# Altium schematic sheet FMDABRadio.SchDoc
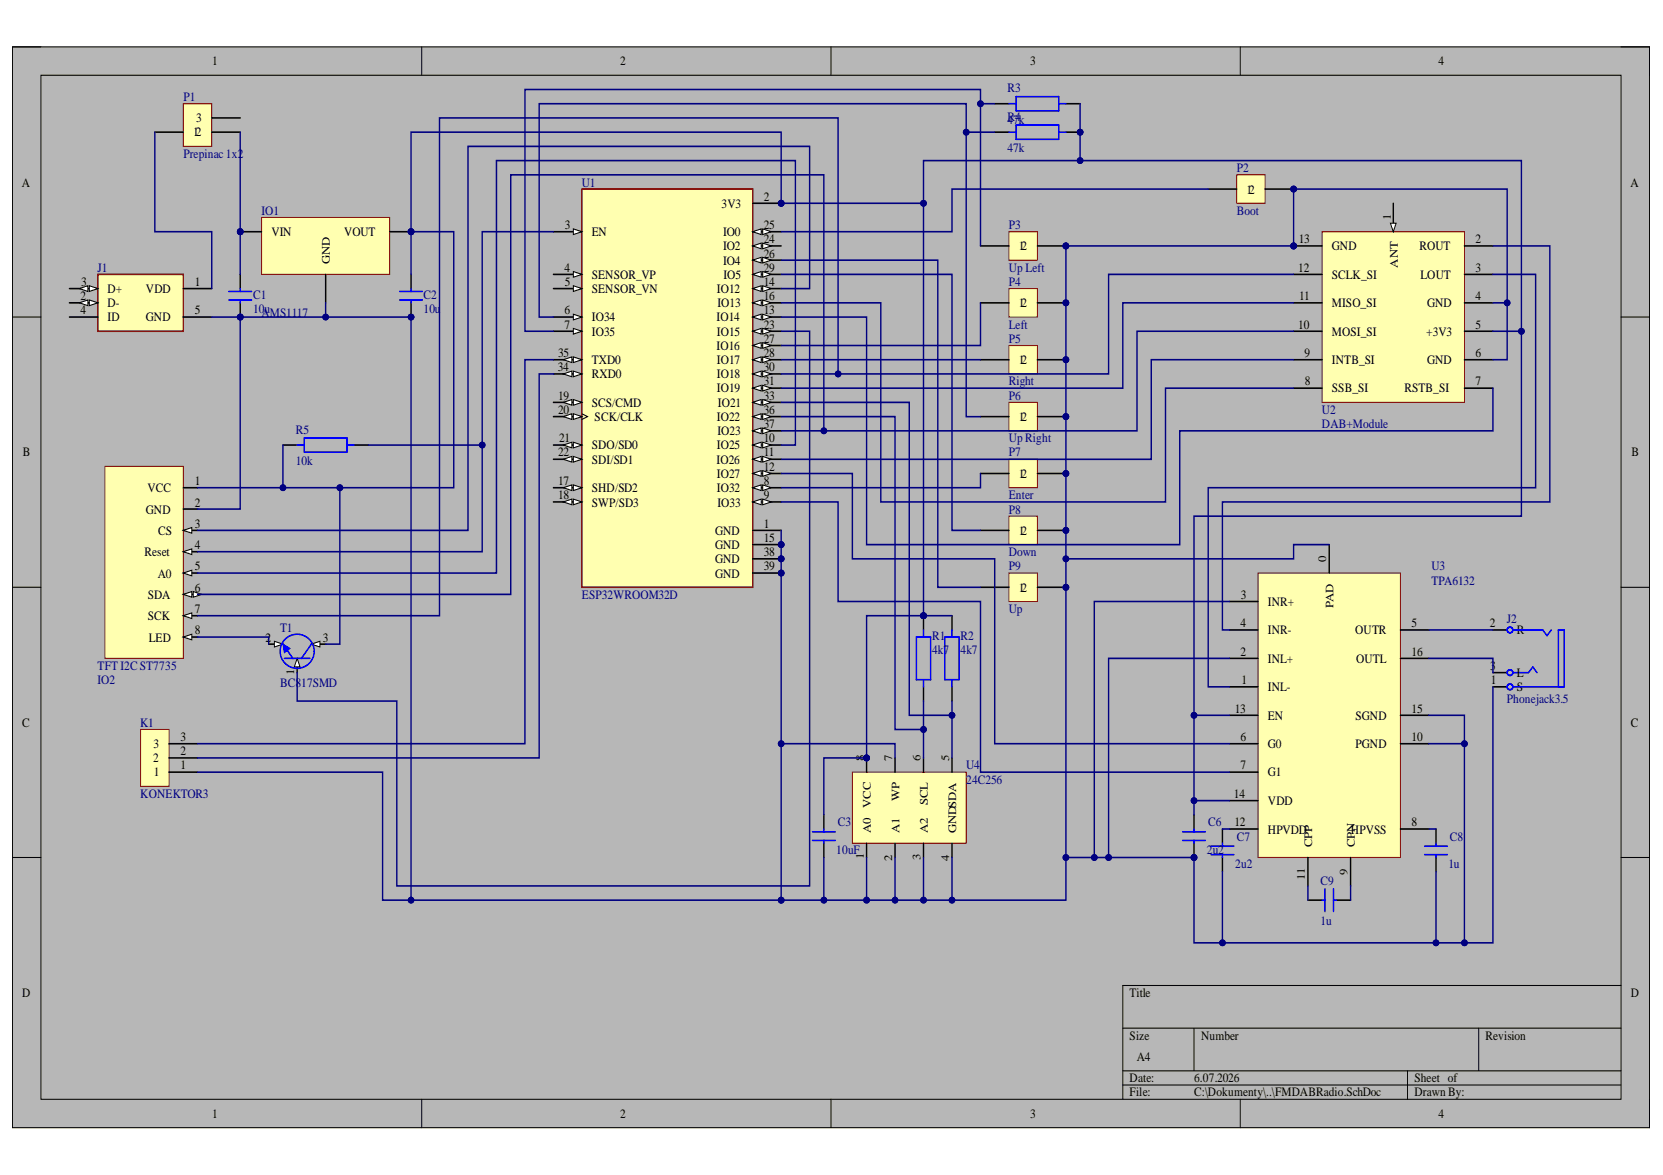
[diagram p0: FMDABRadio.SchDoc]

## Parts
C1  10u  [SMD0805]
C2  10u  [SMD0805]
C3  10uF  [SMD0805]
C6  2u2  [SMD0805]
C7  2u2  [SMD0805]
C8  1u  [SMD0805]
C9  1u  [SMD0805]
IO1  AMS1117  [SOT229]
IO2  TFT 1.8in I2C ST7735  [TFT I2C 1.8in]  (value not drawn)
J1  USBMiniB  [USBMicro SMT V2]  (value not drawn)
J2  Phonejack3.5  [Jack 3.5 mini]
K1  KONEKTOR3  [IL3]
P1  Prepinac 1x2  [Prepinac 1x2 4.8 H]
P2  Boot  [Mikrospinac - SMD]
P3  Up Left  [Mikrospinac]
P4  Left  [Mikrospinac]
P5  Right  [Mikrospinac]
P6  Up Right  [Mikrospinac]
P7  Enter  [Mikrospinac]
P8  Down  [Mikrospinac]
P9  Up  [Mikrospinac]
R1  4k7  [SMD0805]
R2  4k7  [SMD0805]
R3  47k  [SMD0805]
R4  47k  [SMD0805]
R5  10k  [SMD0805]
T1  BC817SMD  [SOT23-3]
U1  ESP32WROOM32D  [ESP32WROOM32D]
U2  DAB+Module  [DAB+Module]
U3  TPA6132  [QFN-16]
U4  24C256  [SO8]

## Nets
5: IO2.6(SDA) IO2.7(SCK) IO2.8(LED)
NetC1_1: C1.1 IO1.3 P1.2
NetC1_2: C1.2 C2.2 C3.2 C6.2 C7.2 C8.2 IO1.1 IO2.2(GND) J1.5(GND) J2.1 K1.1 P2.2 P3.2 P4.2 P5.2 P6.2 P7.2 P8.2 P9.2 U1.1(GND) U1.15(GND) U1.38(GND) U1.39(GND) U2.4(GND) U2.6(GND) U2.13(GND) U3.0(PAD) U3.2(INL+) U3.3(INR+) U3.10(PGND) U3.15(SGND) U4.1(A0) U4.2(A1) U4.3(A2) U4.4(GND) U4.7(WP)
NetC2_1: C2.1 C3.1 C6.1 IO1.2 IO2.1(VCC) R1.1 R2.1 R3.2 R4.2 R5.1 T1.3 U1.2(3V3) U2.5(+3V3) U3.13(EN) U3.14(VDD) U4.8(VCC)
NetC7_1: C7.1 U3.12(HPVDD)
NetC8_1: C8.1 U3.8(HPVSS)
NetC9_1: C9.1 U3.11(CPP)
NetC9_2: C9.2 U3.9(CPN)
NetIO2_3: IO2.3(CS) U1.14(IO12)
NetIO2_4: IO2.4(Reset) R5.2 U1.3(EN)
NetIO2_5: IO2.5(A0) U1.10(IO25)
NetIO2_6: IO2.6(SDA) U1.37(IO23) U2.10(MOSI_SI)
NetIO2_7: IO2.7(SCK) U1.30(IO18) U2.12(SCLK_SI)
NetIO2_8: IO2.8(LED) T1.2
NetJ1_1: J1.1(VDD) P1.1
NetJ2_2: J2.2 U3.5(OUTR)
NetJ2_3: J2.3 U3.16(OUTL)
NetK1_2: K1.2 U1.34(RXD0)
NetK1_3: K1.3 U1.35(TXD0)
NetP2_1: P2.1 U1.25(IO0)
NetP3_1: P3.1 R3.1 U1.7(IO35)
NetP4_1: P4.1 U1.27(IO16)
NetP5_1: P5.1 U1.28(IO17)
NetP6_1: P6.1 R4.1 U1.6(IO34)
NetP7_1: P7.1 U1.8(IO32)
NetP8_1: P8.1 U1.29(IO5)
NetP9_1: P9.1 U1.26(IO4)
NetR1_2: R1.2 U1.36(IO22) U4.6(SCL)
NetR2_2: R2.2 U1.33(IO21) U4.5(SDA)
NetT1_1: T1.1 U1.23(IO15)
NetU1_9: U1.9(IO33) U3.7(G1)
NetU1_11: U1.11(IO26) U2.9(INTB_SI)
NetU1_12: U1.12(IO27) U3.6(G0)
NetU1_13: U1.13(IO14) U2.7(RSTB_SI)
NetU1_16: U1.16(IO13) U2.8(SSB_SI)
NetU1_31: U1.31(IO19) U2.11(MISO_SI)
NetU2_2: U2.2(ROUT) U3.4(INR-)
NetU2_3: U2.3(LOUT) U3.1(INL-)

Not connected: J1 2(D-) 3(D+) 4(ID); P1 3; U1 4(SENSOR_VP) 5(SENSOR_VN) 17(SHD/SD2) 18(SWP/SD3) 19(SCS/CMD) 20(SCK/CLK) 21(SDO/SD0) 22(SDI/SD1) 24(IO2); U2 1(ANT)

## Library data of the parts
C1-C3 C6-C9 = KOND: Capacitor; Component Kind: Standard; Library Name: Misc.schlib; Simulation: CAP
IO1 = AMS1117: 3-Terminal Positive Voltage Regulator 3.3V; Component Kind: Standard; Library Name: Misc.schlib
IO2 = TFT 1.8in I2C ST7735: LCD 1.8in I2C; Component Kind: Standard; Library Name: Misc.schlib
J1 = USBMicroB: USB 2.0 MicroB; ContactMaterial: Copper Alloy; ContactPlating: Gold (30); DrillSize: Corresponds to nominal value.; PanelGround: Without; PCB_Layout: Complies with manufacturer's recommendation.; Component Kind: Standard; ComponentLink1Description: Manufacturer Link; ComponentLink1URL: http://www.tycoelectronics.com/; ComponentLink2Description: Packaging Specification; ComponentLink2URL: http://ecommas.tycoelectronics.com/commerce/DocumentDelivery/DDEController?Action=showdoc&DocId=Customer+Drawing%7F440247%7FD%7Fpdf%7FEnglish%7FC_440247_D.pdf; DatasheetDocument: 27-Jun-2003; HousingMaterial: Thermoplastic; Library Name: Misc.schlib; PackageDocument: 27-Jun-2003; PackageReference: 440247; Published: 15-Jul-2004; Signal Integrity: USB2.0; Publisher: Altium Limited
J2 = Phonejack3.5: Jack Socket 3.5; DrillAndSlotSize: Corresponds to nominal value.; PackageDocument: 2003; PCB_Layout: Complies with manufacturer's recommendation.; Component Kind: Standard; ComponentLink1Description: Manufacturer Link; ComponentLink1URL: http://www.cliffuk.co.uk/; DatasheetDocument: 2003; Library Name: Misc.schlib; PackageReference: S1VS_SBS; Published: 15-Jul-2004; Publisher: Altium Limited
P2-P9 = Prepinac 1x1: Component Kind: Standard; Description: Prepinac 1x1; Library Name: Misc.schlib
R1-R5 = REZISTOR: Resistor; Component Kind: Standard; Library Name: Misc.schlib; Simulation: RESISTOR
U1 = ESP32WROOM32D: ESP32Module; Component Kind: Standard; Library Name: Misc.schlib
U2 = DAB+Module: Si4684 Module; Component Kind: Standard; Library Name: Misc.schlib
U3 = TPA6132: Headphone DirectPath Amplifier; Component Kind: Standard; Library Name: Misc.schlib
U4 = 24C256: Serial EEPROM; Component Kind: Standard; Library Name: Misc.schlib
K1 = KONEKTOR3: Component Kind: Standard; Library Name: Misc.schlib
P1 = Prepinac 1x2: Component Kind: Standard; Description: Prepinac 1x2; Library Name: Misc.schlib
T1 = BC817SMD: Component Kind: Standard; Library Name: Misc.schlib; Simulation: BC368
